# Altium schematic sheet 夏令营主控电路板.SchDoc(夏令营主控电路板)
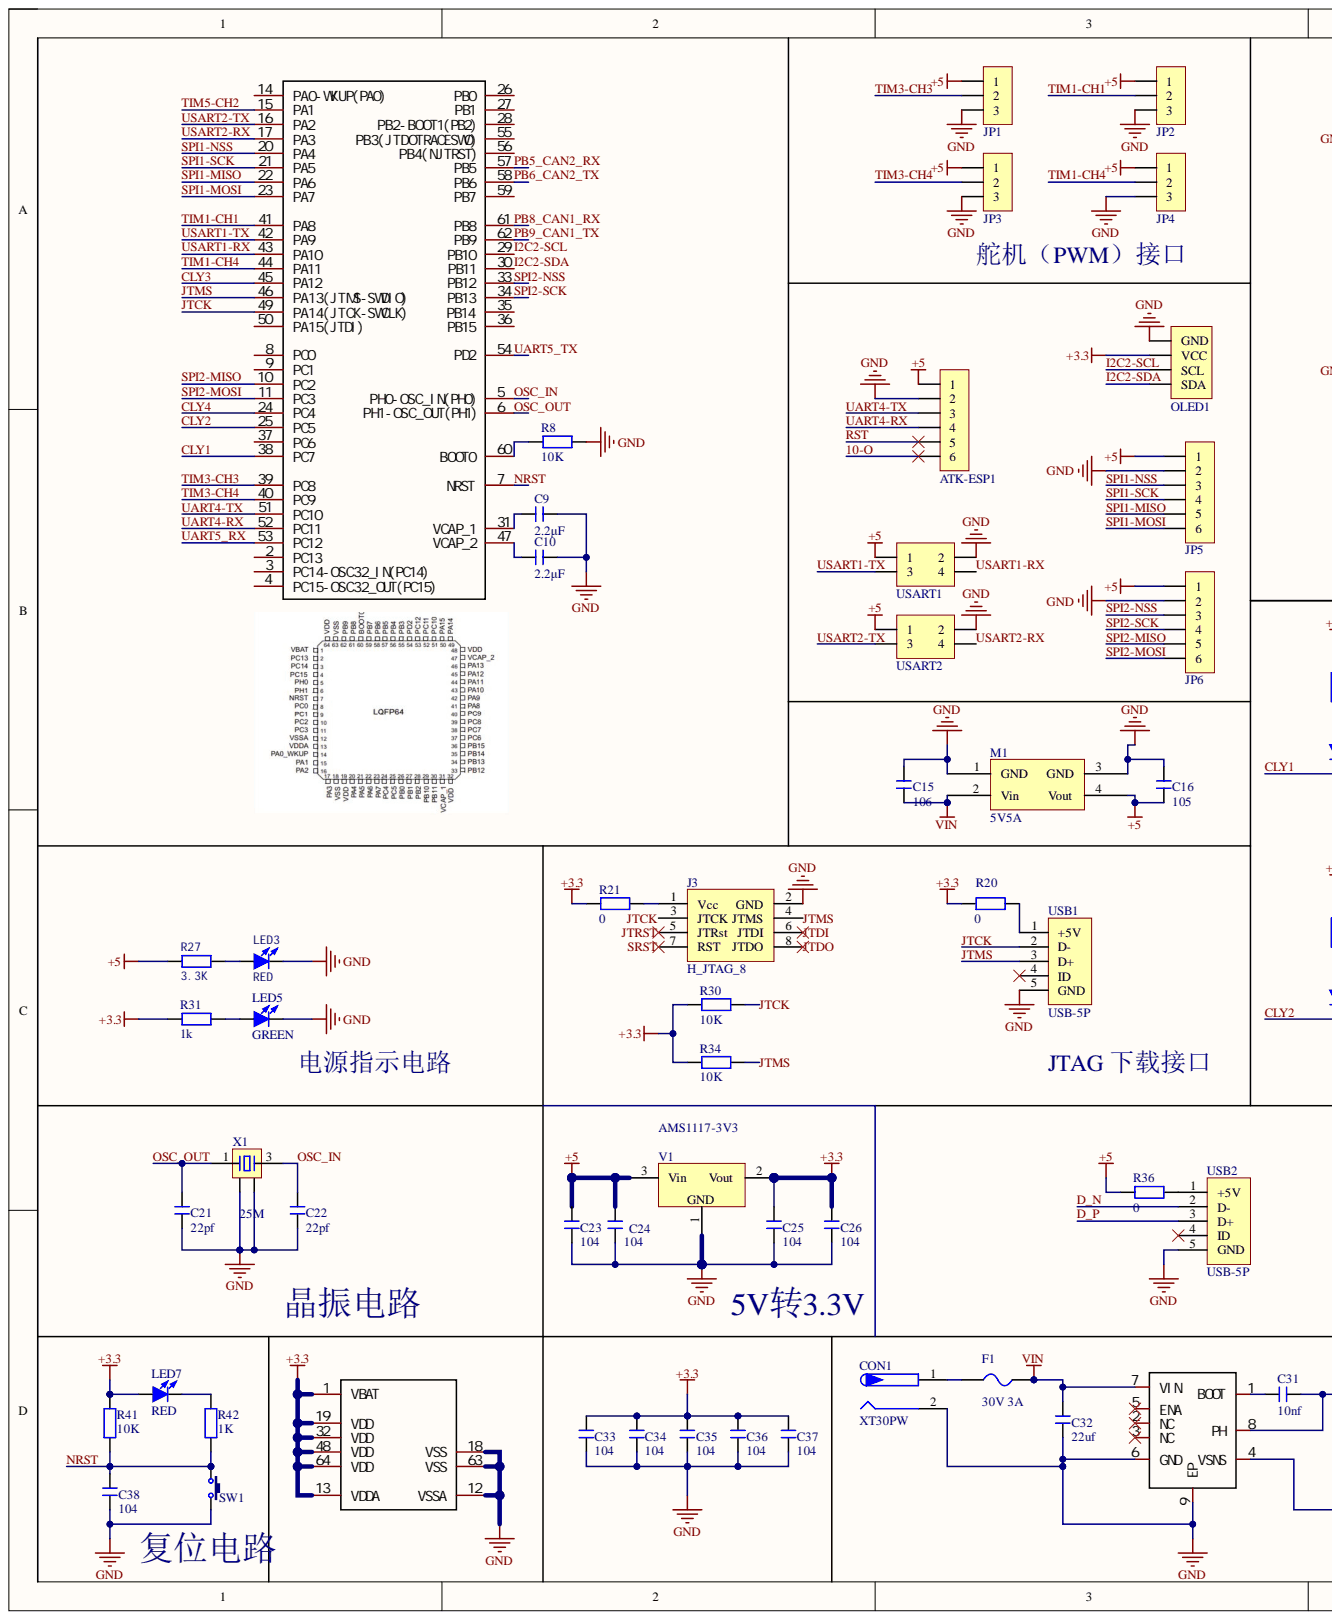
[diagram p0: 夏令营主控电路板.SchDoc, left]
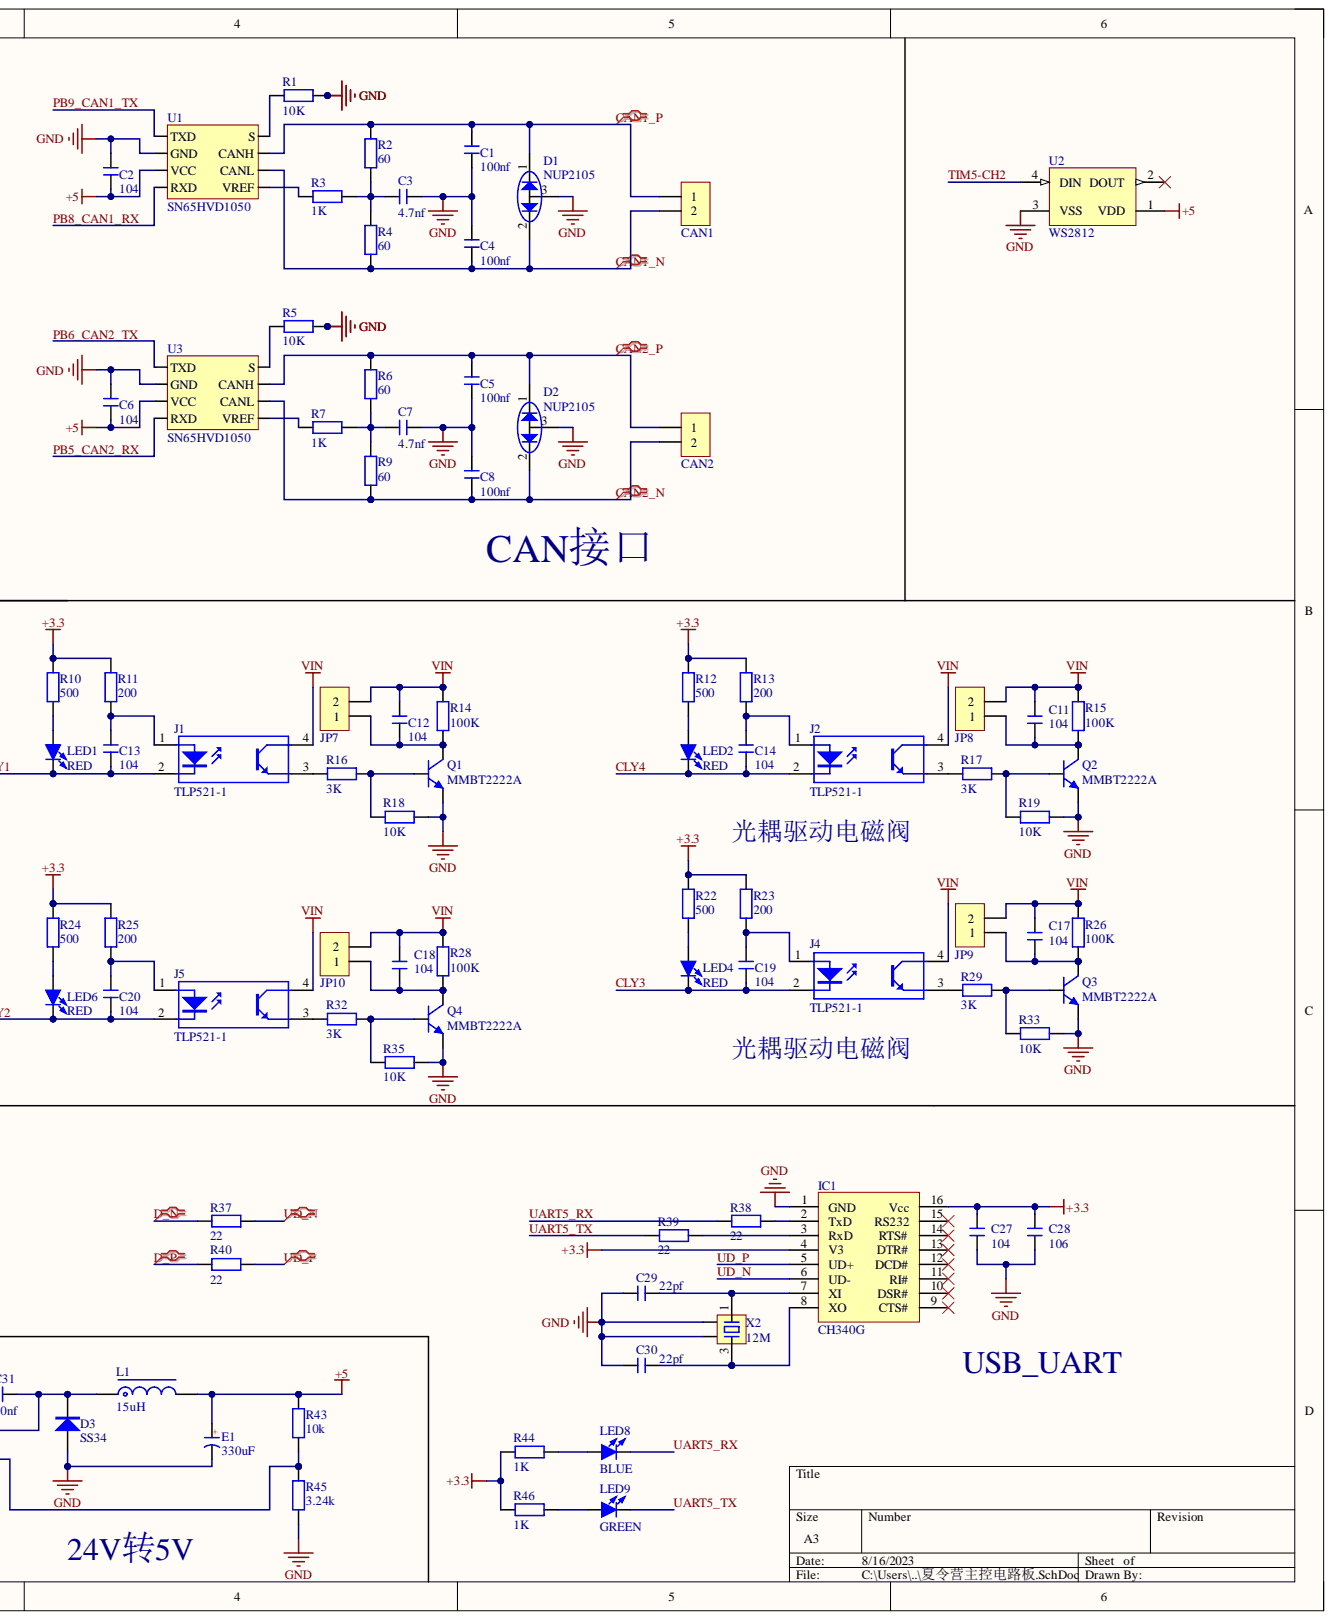
[diagram p1: 夏令营主控电路板.SchDoc, right]

## Parts
ATK-ESP1  HDR-1X6  [ATK-ESP8266]  (value not drawn)
C1  100nf  [C 0603_L]
C2  104  [C 0603_L]
C3  4.7nf  [C 0603_L]
C4  100nf  [C 0603_L]
C5  100nf  [C 0603_L]
C6  104  [C 0603_L]
C7  4.7nf  [C 0603_L]
C8  100nf  [C 0603_L]
C9  2.2μF  [C 0603_L]
C10  2.2μF  [C 0603_L]
C11  104  [C 0603_L]
C12  104  [C 0603_L]
C13  104  [C 0603_L]
C14  104  [C 0603_L]
C15  106  [C 0603_L]
C16  105  [C 0603_L]
C17  104  [C 0603_L]
C18  104  [C 0603_L]
C19  104  [C 0603_L]
C20  104  [C 0603_L]
C21  22pf  [C 0603_L]
C22  22pf  [C 0603_L]
C23  104  [C 0603_L]
C24  104  [C 0603_L]
C25  104  [C 0603_L]
C26  104  [C 0603_L]
C27  104  [C 0603_L]
C28  106  [C 0603_L]
C29  22pf  [C 0603_L]
C30  22pf  [C 0603_L]
C31  10nf  [C 0603_L]
C32  22uf  [C 0603_L]
C33  104  [C 0603_L]
C34  104  [C 0603_L]
C35  104  [C 0603_L]
C36  104  [C 0603_L]
C37  104  [C 0603_L]
C38  104  [C 0603_L]
CAN1  HDR-1X2  [XH2.54-LI-2P]  (value not drawn)
CAN2  HDR-1X2  [XH2.54-LI-2P]  (value not drawn)
CON1  XT30PW  [XT30PW-F]
D1  NUP2105  [SOT23-3L]
D2  NUP2105  [SOT23-3L]
D3  SS34  [SMB]
E1  330uF  [CD A(3216)]
F1  30V 3A  [FU 1206]
IC1  CH340G  [SOP16_L]
J1  TLP521-1  [SOP4-W2.54_N]
J2  TLP521-1  [SOP4-W2.54_N]
J3  H_JTAG_8  [IDC2.54-LI-2x4P]
J4  TLP521-1  [SOP4-W2.54_N]
J5  TLP521-1  [SOP4-W2.54_N]
JP1  HDR-1X3  [XH2.54-LI-3P]  (value not drawn)
JP2  HDR-1X3  [XH2.54-LI-3P]  (value not drawn)
JP3  HDR-1X3  [XH2.54-LI-3P]  (value not drawn)
JP4  HDR-1X3  [XH2.54-LI-3P]  (value not drawn)
JP5  HDR-1X6  [XH2.54-LI-6P]  (value not drawn)
JP6  HDR-1X6  [XH2.54-LI-6P]  (value not drawn)
JP7  HDR-1X2  [XH2.54-LI-2P]  (value not drawn)
JP8  HDR-1X2  [XH2.54-LI-2P]  (value not drawn)
JP9  HDR-1X2  [XH2.54-LI-2P]  (value not drawn)
JP10  HDR-1X2  [XH2.54-LI-2P]  (value not drawn)
L1  15uH  [CD43]
LED1  RED  [LED 0603R]
LED2  RED  [LED 0603R]
LED3  RED  [LED 0603R]
LED4  RED  [LED 0603R]
LED5  GREEN  [LED 0603G]
LED6  RED  [LED 0603R]
LED7  RED  [LED 0603R]
LED8  BLUE  [LED 0603B]
LED9  GREEN  [LED 0603G]
M1  5V5A  [DM21-25W05S]
OLED1  HDR-1X4  [OLED 4Pin 12864]  (value not drawn)
Q1  MMBT2222A  [SOT23-3N]
Q2  MMBT2222A  [SOT23-3N]
Q3  MMBT2222A  [SOT23-3N]
Q4  MMBT2222A  [SOT23-3N]
R1  10K  [R 0603_L]
R2  60  [R 0603_L]
R3  1K  [R 0603_L]
R4  60  [R 0603_L]
R5  10K  [R 0603_L]
R6  60  [R 0603_L]
R7  1K  [R 0603_L]
R8  10K  [R 0603_L]
R9  60  [R 0603_L]
R10  500  [R 0603_L]
R11  200  [R 0603_L]
R12  500  [R 0603_L]
R13  200  [R 0603_L]
R14  100K  [R 0603_L]
R15  100K  [R 0603_L]
R16  3K  [R 0603_L]
R17  3K  [R 0603_L]
R18  10K  [R 0603_L]
R19  10K  [R 0603_L]
R20  0  [R 0603_L]
R21  0  [R 0603_L]
R22  500  [R 0603_L]
R23  200  [R 0603_L]
R24  500  [R 0603_L]
R25  200  [R 0603_L]
R26  100K  [R 0603_L]
R27  3.3K  [R 0603_L]
R28  100K  [R 0603_L]
R29  3K  [R 0603_L]
R30  10K  [R 0603_L]
R31  1k  [R 0603_L]
R32  3K  [R 0603_L]
R33  10K  [R 0603_L]
R34  10K  [R 0603_L]
R35  10K  [R 0603_L]
R36  0  [R 0603_L]
R37  22  [R 0603_L]
R38  22  [R 0603_L]
R39  22  [R 0603_L]
R40  22  [R 0603_L]
R41  10K  [R 0603_L]
R42  1K  [R 0603_L]
R43  10k  [R 0603_L]
R44  1K  [R 0603_L]
R45  3.24k  [R 0603_L]
R46  1K  [R 0603_L]
SW1  3x6x5  [TSW SMD-3*6*2.5]  (value not drawn)
U1  SN65HVD1050  [SOP8_L]
U2  WS2812  [WS2812B_B]
U3  SN65HVD1050  [SOP8_L]
U4  STM32F405RGT6  [LQFP-64_L10.0-W10.0-P0.50-LS12.0-BL]  (value not drawn)  (parts A,B)
U5  TPS5430DDA  [ESOP8_N]  (value not drawn)
USART1  Header2X2  [HDR2.54-LI-2x2P]  (value not drawn)
USART2  Header2X2  [HDR2.54-LI-2x2P]  (value not drawn)
USB1  USB-5P  [USB-MICRO_A]
USB2  USB-5P  [USB-MICRO_A]
V1  AMS1117-3V3  [SOT223_L]
X1  25M  [OSC 2025-4P]
X2  12M  [OSC 2025-4P]

## Nets
+3.3: C25.1 C26.1 C27.2 C28.2 C33.2 C34.2 C35.2 C36.2 C37.2 IC1.4(V3) IC1.16(Vcc) LED7.1 OLED1.2(VCC) R10.2 R11.2 R12.2 R13.2 R20.2 R21.2 R22.2 R23.2 R24.2 R25.2 R30.2 R31.1 R34.2 R41.2 R44.1 R46.1 U4.1(VBAT) U4.13(VDDA) U4.19(VDD) U4.32(VDD) U4.48(VDD) U4.64(VDD) V1.2
+5: ATK-ESP1.1 C2.1 C6.1 C16.1 C23.2 C24.1 E1.1 JP1.1 JP2.1 JP3.1 JP4.1 JP5.1 JP6.1 L1.2 M1.4(Vout) R27.1 R36.1 R43.2 U1.3(VCC) U2.1(VDD) U3.3(VCC) USART1.1 USART2.1 V1.3
GND: ATK-ESP1.2 C1.1 C2.2 C3.2 C4.2 C5.1 C6.2 C7.2 C8.2 C9.1 C10.1 C15.2 C16.2 C21.2 C22.2 C23.1 C24.2 C25.2 C26.2 C27.1 C28.1 C29.2 C30.2 C32.1 C33.1 C34.1 C35.1 C36.1 C37.1 C38.1 CON1.2 D1.3 D2.3 D3.1 E1.2 IC1.1(GND) J3.2(GND) JP1.3 JP2.3 JP3.3 JP4.3 JP5.2 JP6.2 LED3.2 LED5.2 M1.1(GND) M1.3(GND) OLED1.1(GND) Q1.2 Q2.2 Q3.2 Q4.2 R1.2 R5.2 R8.2 R18.2 R19.2 R33.2 R35.2 R45.1 SW1.2 U1.2(GND) U2.3(VSS) U3.2(GND) U4.12(VSSA) U4.18(VSS) U4.63(VSS) U5.6(GND) U5.9(EP) USART1.2 USART2.2 USB1.5(GND) USB2.5(GND) V1.1 X1.2 X1.4 X2.2 X2.4
VIN: C11.2 C12.2 C15.1 C17.2 C18.2 C32.2 F1.2 J1.4 J2.4 J4.4 J5.4 JP7.2 JP8.2 JP9.2 JP10.2 M1.2(Vin) R14.2 R15.2 R26.2 R28.2 U5.7(VIN)
10-O: ATK-ESP1.6
CAN1_N: C4.1 CAN1.2 D1.2 R4.1 U1.6(CANL)
CAN1_P: C1.2 CAN1.1 D1.1 R2.2 U1.7(CANH)
CAN2_N: C8.1 CAN2.2 D2.2 R9.1 U3.6(CANL)
CAN2_P: C5.2 CAN2.1 D2.1 R6.2 U3.7(CANH)
CLY1: C13.1 J1.2 LED1.2 U4.38(PC7)
CLY2: C20.1 J5.2 LED6.2 U4.25(PC5)
CLY3: C19.1 J4.2 LED4.2 U4.45(PA12)
CLY4: C14.1 J2.2 LED2.2 U4.24(PC4)
D_N: R37.1 USB2.2(D-)
D_P: R40.1 USB2.3(D+)
I2C2-SCL: OLED1.3(SCL) U4.29(PB10)
I2C2-SDA: OLED1.4(SDA) U4.30(PB11)
JTCK: J3.3(JTCK) R30.1 U4.49(PA14(JTCK-SWCLK)) USB1.2(D-)
JTDI: J3.6(JTDI)
JTDO: J3.8(JTDO)
JTMS: J3.4(JTMS) R34.1 U4.46(PA13(JTMS-SWDIO)) USB1.3(D+)
JTRST: J3.5(JTRst)
NRST: C38.2 R41.1 R42.1 SW1.1 U4.7(NRST)
OSC_IN: C22.1 U4.5(PH0-OSC_IN(PH0)) X1.3
OSC_OUT: C21.1 U4.6(PH1-OSC_OUT(PH1)) X1.1
PB5_CAN2_RX: U3.4(RXD) U4.57(PB5)
PB6_CAN2_TX: U3.1(TXD) U4.58(PB6)
PB8_CAN1_RX: U1.4(RXD) U4.61(PB8)
PB9_CAN1_TX: U1.1(TXD) U4.62(PB9)
RST: ATK-ESP1.5
SPI1-MISO: JP5.5 U4.22(PA6)
SPI1-MOSI: JP5.6 U4.23(PA7)
SPI1-NSS: JP5.3 U4.20(PA4)
SPI1-SCK: JP5.4 U4.21(PA5)
SPI2-MISO: JP6.5 U4.10(PC2)
SPI2-MOSI: JP6.6 U4.11(PC3)
SPI2-NSS: JP6.3 U4.33(PB12)
SPI2-SCK: JP6.4 U4.34(PB13)
SRST: J3.7(RST)
TIM1-CH1: JP2.2 U4.41(PA8)
TIM1-CH4: JP4.2 U4.44(PA11)
TIM3-CH3: JP1.2 U4.39(PC8)
TIM3-CH4: JP3.2 U4.40(PC9)
TIM5-CH2: U2.4(DIN) U4.15(PA1)
UART4-RX: ATK-ESP1.4 U4.52(PC11)
UART4-TX: ATK-ESP1.3 U4.51(PC10)
UART5_RX: LED8.2 R38.1 U4.53(PC12)
UART5_TX: LED9.2 R39.1 U4.54(PD2)
UD_N: IC1.6(UD-) R37.2
UD_P: IC1.5(UD+) R40.2
USART1-RX: U4.43(PA10) USART1.4
USART1-TX: U4.42(PA9) USART1.3
USART2-RX: U4.17(PA3) USART2.4
USART2-TX: U4.16(PA2) USART2.3
NetC3_1: C3.1 R2.1 R3.2 R4.2
NetC7_1: C7.1 R6.1 R7.2 R9.2
NetC9_2: C9.2 U4.31(VCAP_1)
NetC10_2: C10.2 U4.47(VCAP_2)
NetC11_1: C11.1 JP8.1 Q2.3 R15.1
NetC12_1: C12.1 JP7.1 Q1.3 R14.1
NetC13_2: C13.2 J1.1 R11.1
NetC14_2: C14.2 J2.1 R13.1
NetC17_1: C17.1 JP9.1 Q3.3 R26.1
NetC18_1: C18.1 JP10.1 Q4.3 R28.1
NetC19_2: C19.2 J4.1 R23.1
NetC20_2: C20.2 J5.1 R25.1
NetC29_1: C29.1 IC1.7(XI) X2.1
NetC30_1: C30.1 IC1.8(XO) X2.3
NetC31_1: C31.1 U5.1(BOOT)
NetC31_2: C31.2 D3.2 L1.1 U5.8(PH)
NetCON1_1: CON1.1 F1.1
NetIC1_2: IC1.2(TxD) R38.2
NetIC1_3: IC1.3(RxD) R39.2
NetJ1_3: J1.3 R16.1
NetJ2_3: J2.3 R17.1
NetJ3_1: J3.1(Vcc) R21.1
NetJ4_3: J4.3 R29.1
NetJ5_3: J5.3 R32.1
NetLED1_1: LED1.1 R10.1
NetLED2_1: LED2.1 R12.1
NetLED3_1: LED3.1 R27.2
NetLED4_1: LED4.1 R22.1
NetLED5_1: LED5.1 R31.2
NetLED6_1: LED6.1 R24.1
NetLED7_2: LED7.2 R42.2
NetLED8_1: LED8.1 R44.2
NetLED9_1: LED9.1 R46.2
NetQ1_1: Q1.1 R16.2 R18.1
NetQ2_1: Q2.1 R17.2 R19.1
NetQ3_1: Q3.1 R29.2 R33.1
NetQ4_1: Q4.1 R32.2 R35.1
NetR1_1: R1.1 U1.8(S)
NetR3_1: R3.1 U1.5(VREF)
NetR5_1: R5.1 U3.8(S)
NetR7_1: R7.1 U3.5(VREF)
NetR8_1: R8.1 U4.60(BOOT0)
NetR20_1: R20.1 USB1.1(+5V)
NetR36_2: R36.2 USB2.1(+5V)
NetR43_1: R43.1 R45.2 U5.4(VSNS)

Not connected: IC1 9(CTS#) 10(DSR#) 11(RI#) 12(DCD#) 13(DTR#) 14(RTS#) 15(RS232); U2 2(DOUT); U4 2(PC13) 3(PC14-OSC32_IN(PC14)) 4(PC15-OSC32_OUT(PC15)) 8(PC0) 9(PC1) 14(PA0-WKUP(PA0)) 26(PB0) 27(PB1) 28(PB2-BOOT1(PB2)) 35(PB14) 36(PB15) 37(PC6) 50(PA15(JTDI)) 55(PB3(JTDOTRACESWO)) 56(PB4(NJTRST)) 59(PB7); U5 2(NC) 3(NC) 5(ENA); USB1 4(ID); USB2 4(ID)

## Library data of the parts
ATK-ESP1 JP5 JP6 = Header 6: 6P接插件; 版权归属: 源创客; BOM名称: 6P接插件; Component Kind: Standard; Library Name: 4.5   - 接插件-脚距2.54.SchLib; 学习资源: www.ooocke.com
C1-C38 = C: 无极性贴片电容; 版权归属: 源创客; BOM名称: 无极性贴片电容; Component Kind: Standard; Library Name: 3.1   - 电阻-电容-电感.SchLib; 学习资源: www.ooocke.com
CAN1 CAN2 JP7-JP10 = Header 2: 2P接插件; 版权归属: 源创客; BOM名称: 2P接插件; Component Kind: Standard; Library Name: 4.5   - 接插件-脚距2.54.SchLib; 学习资源: www.ooocke.com
CON1 = XT30PW-M: XT30PW-M PCB板专用卧式连接器，低温升阻燃插头; Component Kind: Standard; Library Name: 15.1 - 未分类器件.SchLib
D1 D2 = BAT54A: 表贴肖特基二极管; BOM名称: 肖特基二极管; 属性: 30V/0.2A; Component Kind: Standard; Library Name: 8.1   - 二极管-整流桥.SchLib
D3 = SS34: 肖特基二极管; 属性: 40V/3A; 版权归属: 源创客; BOM名称: 肖特基二极管; Component Kind: Standard; Library Name: 8.1   - 二极管-整流桥.SchLib; 学习资源: www.ooocke.com
E1 = C-CD: 贴片钽电容; 版权归属: 源创客; BOM名称: 贴片钽电容; Component Kind: Standard; Library Name: 3.1   - 电阻-电容-电感.SchLib; 学习资源: www.ooocke.com
F1 = FU: 贴片保险丝; 版权归属: 源创客; BOM名称: 贴片保险丝; Component Kind: Standard; Library Name: 10.1 - 保险丝-晶振.SchLib; 学习资源: www.ooocke.com
IC1 = CH340G: 串口转USB; 版权归属: 源创客; BOM名称: 串口转USB; Component Kind: Standard; Library Name: 1.1   - IC芯片.SchLib; 学习资源: www.ooocke.com
J1 J2 J4 J5 = TLP521-1: 1路开关光耦; 版权归属: 源创客; BOM名称: 1路开关光耦; Component Kind: Standard; Library Name: 11.1 - 传感元件.SchLib; 学习资源: www.ooocke.com
J3 = H_JTAG_8: 8脚JTAG下载接口; Component Kind: Standard; Library Name: 接插件.SCHLIB
JP1-JP4 = Header 3: 3P接插件; 版权归属: 源创客; BOM名称: 3P接插件; Component Kind: Standard; Library Name: 4.5   - 接插件-脚距2.54.SchLib; 学习资源: www.ooocke.com
L1 = L-CD: 贴片功率电感; BOM名称: 功率电感; 版权归属: 源创客; Component Kind: Standard; Library Name: 3.1   - 电阻-电容-电感.SchLib; 学习资源: www.ooocke.com
LED1 LED2 LED4 LED6-LED9 = LED-SMD: 贴片LED; 版权归属: 源创客; BOM名称: 贴片LED; Component Kind: Standard; Library Name: 9.1   - LED.SchLib; 学习资源: www.ooocke.com
LED3 LED5 = LED-SMD: 贴片LED; BOM名称: 贴片LED; Component Kind: Standard; Library Name: 9.1   - LED.SchLib
M1 = DM21-25W05S: Component Kind: Standard; Library Name: 机器人队常用模块.SchLib
OLED1 = OLED_I2C_128*64: OLED-4P接插件; BOM名称: 4P接插件; Component Kind: Standard; Library Name: 机器人队常用模块.SchLib
Q1-Q4 = MMBT2222A-SMD: 高频放大-NPN型; 属性: 40V 1.5A 1W 100MHZ; 版权归属: 源创客; BOM名称: 高频放大-NPN型; Component Kind: Standard; Library Name: 6.2   - 三极管.SchLib; 学习资源: www.ooocke.com
R1-R19 R22-R26 R28 R29 R32 R33 R35-R46 = R: 贴片电阻; 版权归属: 源创客; BOM名称: 贴片电阻; Component Kind: Standard; Library Name: 3.1   - 电阻-电容-电感.SchLib; 学习资源: www.ooocke.com
R20 R21 R27 R30 R31 R34 = R: 贴片电阻; BOM名称: 贴片电阻; Component Kind: Standard; Library Name: 3.1   - 电阻-电容-电感.SchLib
SW1 = TSW 3x6: 3x6轻触开关; 版权归属: 源创客; BOM名称: 3x6轻触开关; Component Kind: Standard; Library Name: 5.1   - 按键-开关.SchLib; 学习资源: www.ooocke.com
U1 U3 = SN65HVD1050: EMC Optimized CAN Bus Transceiver; Component Kind: Standard; Library Name: 高级IC.SchLib
U2 = WS2812: Intelligent Control LED Integrated Light Source, 3.5 to 5.3 V, -25 to 80 degC, 4-Pin SMD, RoHS, Bulk; PartNumber: WS2812B; Case\Package: Radial; Current Rating: 240 mA; Shielding: Unshielded; Tolerance: 10%; Component Kind: Standard; ComponentLink1Description: Manufacturer URL; ComponentLink1URL: https://www.adafruit.com/; ComponentLink2Description: Datasheet; ComponentLink2URL: https://cdn-shop.adafruit.com/datasheets/WS2812B.pdf; DatasheetVersion: May-13; Height: 12.2 mm; Lead Pitch: 5 mm; Length: 12.2 mm; Library Name: 9.1   - LED.SchLib; Max Operating Temperature: 125 degC; Min Operating Temperature: -55 degC; Mount: Through Hole; Package Quantity: 200; PackageDescription: 4-Pin Surface Mount Device, Body 5 x 5 mm, Pitch 3.3 mm; PackageReference: WS2812B; Packaging: Bulk; Pins: 2; Radiation Hardening: No; REACH SVHC: No SVHC; RoHSCompliant: true
U4 = STM32F405RGT6: Manufacturer: ST(意法半导体); ADC (Bit): 12bit; Add into BOM: yes; CPU Core: ARM Cortex-M4; CPU Maximum Speed: 168MHz; Direct Memory Access: 有; GPIO Ports Number: 51; Operating Voltage Range: 1.8V~3.6V; Peripheral/Function: DMA；LCD/LED驱动；PWM; Program Memory Type: FLASH; Program Storage Size: 1MB; RAM Size: 192KB; CCP Capture/Compare: -; communication protocol: -; Component Kind: Standard; Convert to PCB: yes; DAC (Bit): 12bit; Datasheet: https://atta.szlcsc.com/upload/public/pdf/source/20140724/1457707160365.pdf; Device: STM32F405RGT6; EEPROM: -; Infrared Data Association: 有; Internal Comparator: -; Internal Oscillator: 有; JLCPCB Part Class: Extended Part; LCD Module: 有; LCSC Part Name: ARM Cortex-M4 168MHz; Library Name: STM32F405RGT6.IntLib; Low-Voltage Detect: 有; Manufacturer Part: STM32F405RGT6; Name: STM32F405RGT6; Operating Temperature Range: -40℃~+85℃; PCB3D: LQFP-64_L10.0-W10.0-H1.4-LS12.0-P0.50; PWM (Bit): -; Real-Time Clock: -; Secure Digital Input and Output: -; Supplier: LCSC; Supplier Footprint: LQFP-64(10x10); Supplier Part: C15742; Symbol: STM32F405RGT6; Universal Serial Bus: 有; Watchdog: 有
U5 = TPS5430DDA: Manufacturer: TI(德州仪器); Add into BOM: yes; Function: 降压型; Input Voltage: 5.5V~36V; Number of Outputs: 1; Output Current (Max): 3A; Output Type: 可调; Output Voltage: 1.221V~32.04V; Switching Frequency: 500kHz; Synchronous Rectification: 否; Topology: 降压式; Component Kind: Standard; Convert to PCB: yes; Device: TPS5430DDA; JLCPCB Part Class: Extended Part; LCSC Part Name: 降压型 Vin=5.5V~36V Vout=1.221V~32.04V 3A; Library Name: 1.3   - 电源类芯片.SchLib; Manufacturer Part: TPS5430DDA; Name: TPS5430DDA; Operating Temperature: -40℃~+125℃@(TJ); Supplier: LCSC; Supplier Footprint: SO-8-EP; Supplier Part: C502477; Symbol: TPS5430DDA
USART1 USART2 = Header 2X2: 2*2P接插件; 版权归属: 源创客; BOM名称: 2*2P接插件; Component Kind: Standard; Library Name: 4.5   - 接插件-脚距2.54.SchLib; 学习资源: www.ooocke.com
USB1 USB2 = USB-5P: 微型USB母座; 版权归属: 源创客; BOM名称: 微型USB母座; Component Kind: Standard; Library Name: 4.1   - 接口类.SchLib; 学习资源: www.ooocke.com
V1 = AMS1117: 三端稳压芯片; BOM名称: 稳压芯片; 版权归属: 源创客; Component Kind: Standard; Library Name: 1.3   - 电源类芯片.SchLib; 学习资源: www.ooocke.com
X1 X2 = XTAL-4P: 4脚无源晶振; 版权归属: 源创客; BOM名称: 4脚无源晶振; Component Kind: Standard; Library Name: 10.1 - 保险丝-晶振.SchLib; 学习资源: www.ooocke.com
